# Altium schematic sheet 06_ACCELEROMETER_FLASH_WIND.SchDoc(06_ACCELEROMETER_FLASH_WIND)
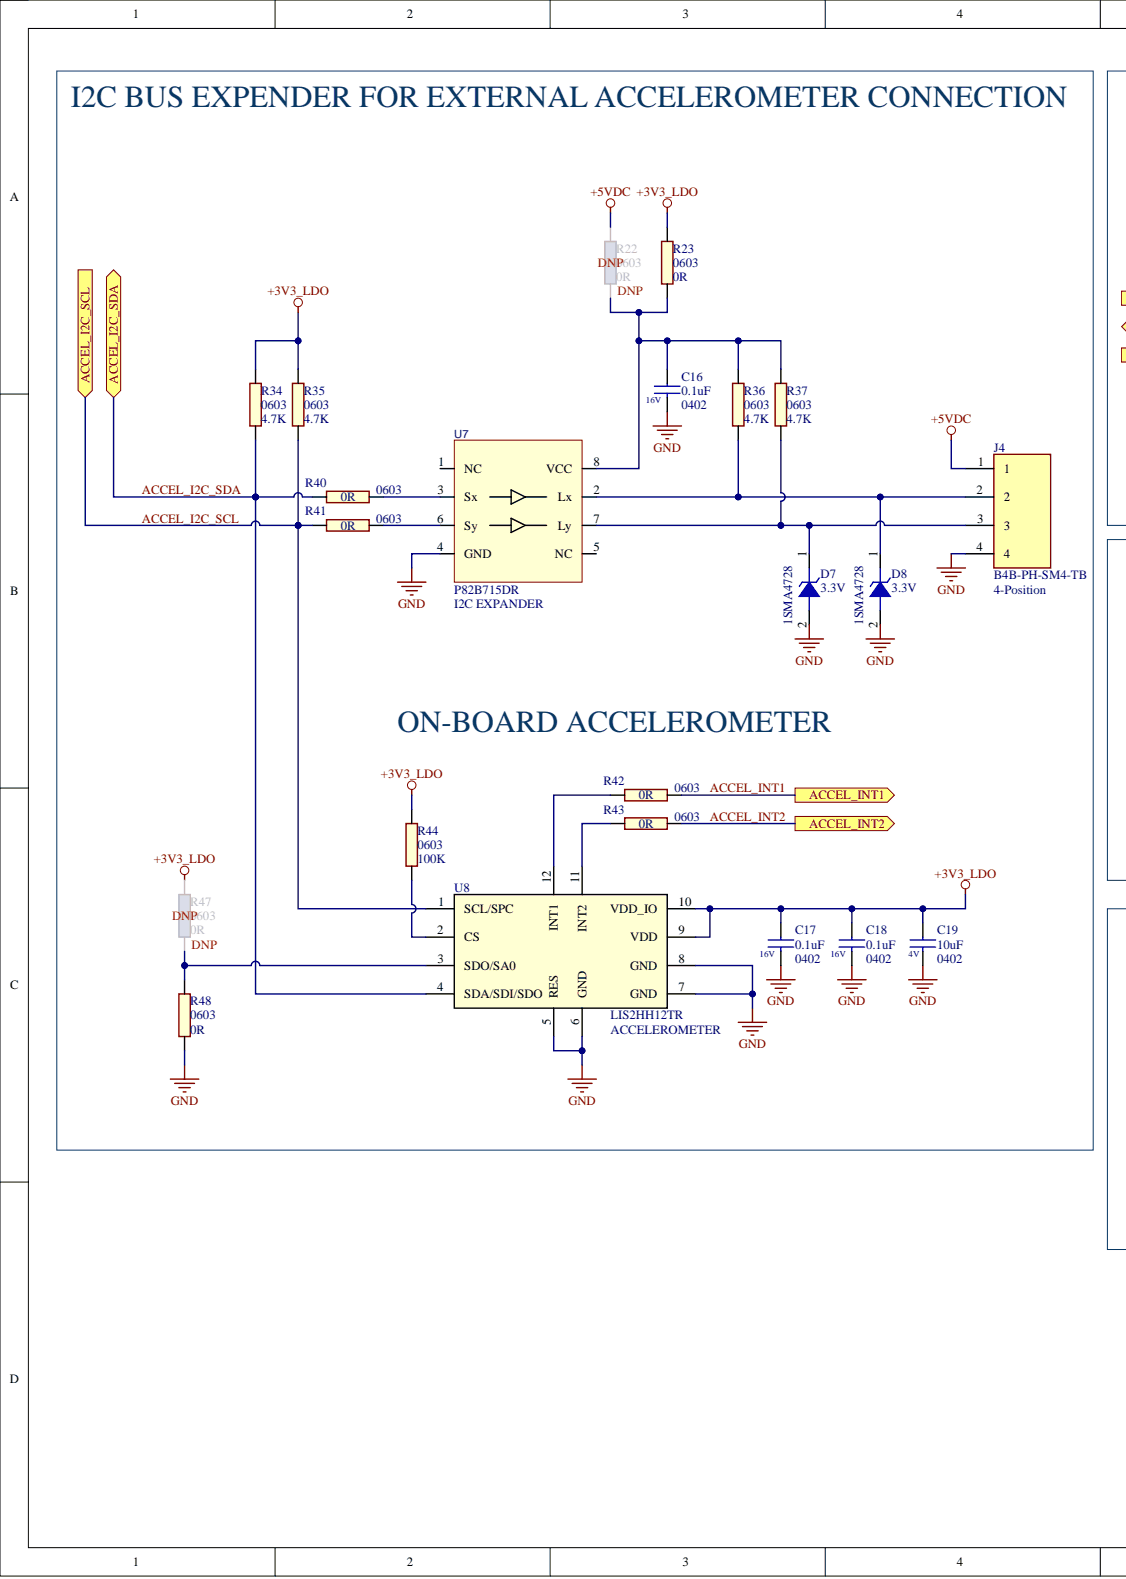
[diagram p0: 06_ACCELEROMETER_FLASH_WIND.SchDoc, left]
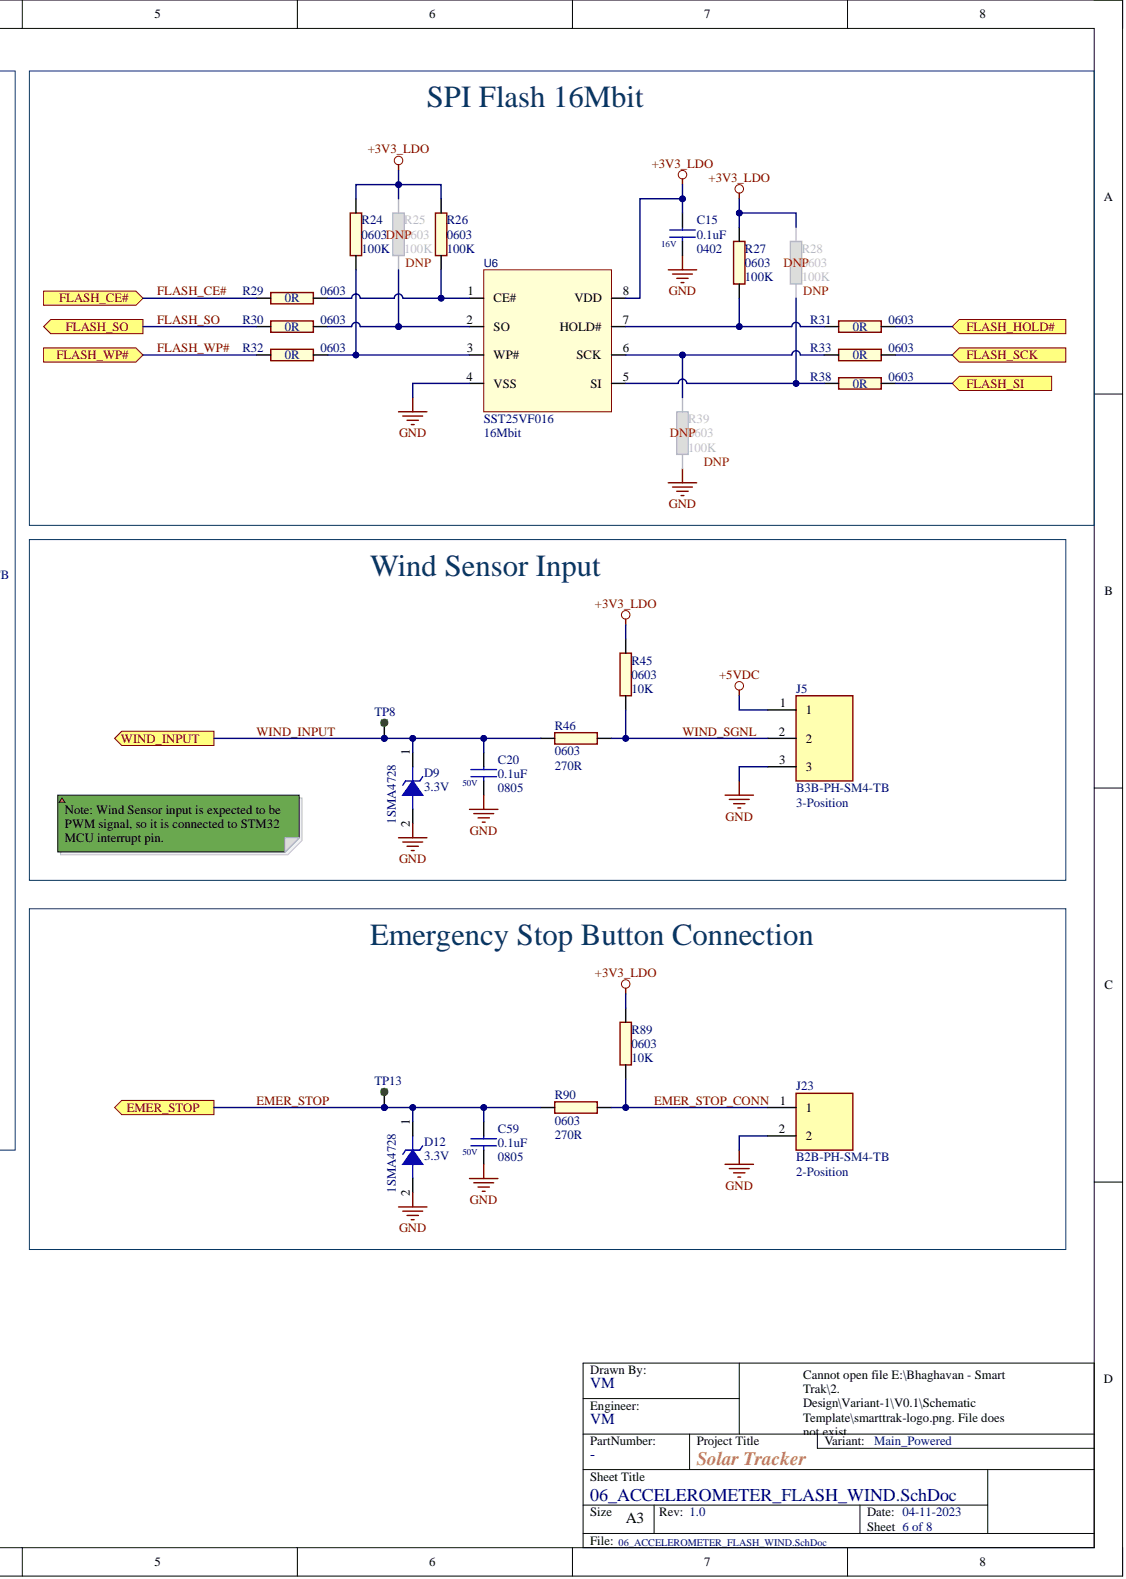
[diagram p1: 06_ACCELEROMETER_FLASH_WIND.SchDoc, right]

## Parts
C15  value 0.1uF  Note: 0402  Voltage - Rated: 16V  [C0402]  (hidden comment: CAP_0.1uF_0402_16V)
C16  value 0.1uF  Note: 0402  Voltage - Rated: 16V  [C0402]  (hidden comment: CAP_0.1uF_0402_16V)
C17  value 0.1uF  Note: 0402  Voltage - Rated: 16V  [C0402]  (hidden comment: CAP_0.1uF_0402_16V)
C18  value 0.1uF  Note: 0402  Voltage - Rated: 16V  [C0402]  (hidden comment: CAP_0.1uF_0402_16V)
C19  value 10uF  Note: 0402  Voltage - Rated: 4V  [C0402]  (hidden comment: CAP_10uF_0402_4V)
C20  value 0.1uF  Note: 0805  Voltage - Rated: 50V  [C0805]  (hidden comment: CAP_0.1uF_0805_50V)
C59  value 0.1uF  Note: 0805  Voltage - Rated: 50V  [C0805]  (hidden comment: CAP_0.1uF_0805_50V)
D7  value 1SMA4728  Note: 3.3V  [SOD5027X250N_2A]  (hidden comment: ZENER_SMD_3.3V_1SMA4728)
D8  value 1SMA4728  Note: 3.3V  [SOD5027X250N_2A]  (hidden comment: ZENER_SMD_3.3V_1SMA4728)
D9  value 1SMA4728  Note: 3.3V  [SOD5027X250N_2A]  (hidden comment: ZENER_SMD_3.3V_1SMA4728)
D12  value 1SMA4728  Note: 3.3V  [SOD5027X250N_2A]  (hidden comment: ZENER_SMD_3.3V_1SMA4728)
J4  value B4B-PH-SM4-TB  MPN: B4B-PH-SM4-TB  Note: 4-Position  [JST_B4B-PH-SM4-TB]  (hidden comment: CONN_4PIN_B4B-PH-SM4-TB)
J5  value B3B-PH-SM4-TB  MPN: B3B-PH-SM4-TB  Note: 3-Position  [JST_B3B-PH-SM4-TB]  (hidden comment: CONN_3PIN_B3B-PH-SM4-TB)
J23  value B2B-PH-SM4-TB  MPN: B2B-PH-SM4-TB  Note: 2-Position  [JST_B2B-PH-SM4-TB]  (hidden comment: CONN_2PIN_B2B-PH-SM4-TB)
R22  value 0R  Note: 0603  [R0603]  (hidden comment: RES_0R_0603)
R23  value 0R  Note: 0603  [R0603]  (hidden comment: RES_0R_0603)
R24  value 100K  Note: 0603  [R0603]  (hidden comment: RES_100K_0603)
R25  value 100K  Note: 0603  [R0603]  (hidden comment: RES_100K_0603)
R26  value 100K  Note: 0603  [R0603]  (hidden comment: RES_100K_0603)
R27  value 100K  Note: 0603  [R0603]  (hidden comment: RES_100K_0603)
R28  value 100K  Note: 0603  [R0603]  (hidden comment: RES_100K_0603)
R29  value 0R  Note: 0603  [R0603]  (hidden comment: RES_0R_0603)
R30  value 0R  Note: 0603  [R0603]  (hidden comment: RES_0R_0603)
R31  value 0R  Note: 0603  [R0603]  (hidden comment: RES_0R_0603)
R32  value 0R  Note: 0603  [R0603]  (hidden comment: RES_0R_0603)
R33  value 0R  Note: 0603  [R0603]  (hidden comment: RES_0R_0603)
R34  value 4.7K  Note: 0603  [R0603]  (hidden comment: RES_4.7K_0603)
R35  value 4.7K  Note: 0603  [R0603]  (hidden comment: RES_4.7K_0603)
R36  value 4.7K  Note: 0603  [R0603]  (hidden comment: RES_4.7K_0603)
R37  value 4.7K  Note: 0603  [R0603]  (hidden comment: RES_4.7K_0603)
R38  value 0R  Note: 0603  [R0603]  (hidden comment: RES_0R_0603)
R39  value 100K  Note: 0603  [R0603]  (hidden comment: RES_100K_0603)
R40  value 0R  Note: 0603  [R0603]  (hidden comment: RES_0R_0603)
R41  value 0R  Note: 0603  [R0603]  (hidden comment: RES_0R_0603)
R42  value 0R  Note: 0603  [R0603]  (hidden comment: RES_0R_0603)
R43  value 0R  Note: 0603  [R0603]  (hidden comment: RES_0R_0603)
R44  value 100K  Note: 0603  [R0603]  (hidden comment: RES_100K_0603)
R45  value 10K  Note: 0603  [R0603]  (hidden comment: RES_10K_0603)
R46  value 270R  Note: 0603  [R0603]  (hidden comment: RES_270R_0603)
R47  value 0R  Note: 0603  [R0603]  (hidden comment: RES_0R_0603)
R48  value 0R  Note: 0603  [R0603]  (hidden comment: RES_0R_0603)
R89  value 10K  Note: 0603  [R0603]  (hidden comment: RES_10K_0603)
R90  value 270R  Note: 0603  [R0603]  (hidden comment: RES_270R_0603)
TP8  TP_GREEN_5121  [KEYSTONE_5121]  (value not drawn)
TP13  TP_GREEN_5121  [KEYSTONE_5121]  (value not drawn)
U6  value SST25VF016  Note: 16Mbit  [SOIC127P800X216-8N]  (hidden comment: FLASH_16MBIT_SST25VF016)
U7  value P82B715DR  MPN: P82B715DR  Note: I2C EXPANDER  [SOIC127P600X175-8N]  (hidden comment: REDRIVER_I2C_P82B715DR)
U8  value LIS2HH12TR  MPN: LIS2HH12TR  Note: ACCELEROMETER  [LGA12S50P4X4_200X200X100N]  (hidden comment: ACCEELROMETER_LIS2HH12TR)

## Ports
ACCEL_I2C_SCL, ACCEL_I2C_SDA, ACCEL_INT1, ACCEL_INT2, EMER_STOP, FLASH_CE#, FLASH_HOLD#, FLASH_SCK, FLASH_SI, FLASH_SO, FLASH_WP#, WIND_INPUT

## Nets
+3V3_LDO: C15.1 C17.1 C18.1 C19.1 R23.2 R24.2 R25.2 R26.2 R27.2 R28.2 R34.2 R35.2 R44.2 R45.2 R47.2 R89.2 U6.8(VDD) U8.9(VDD) U8.10(VDD_IO)
+5VDC: J4.1 J5.1 R22.2
GND: C15.2 C16.2 C17.2 C18.2 C19.2 C20.2 C59.2 D7.2 D8.2 D9.2 D12.2 J4.4 J5.3 J23.2 R39.1 R48.1 U6.4(VSS) U7.4(GND) U8.5(RES) U8.6(GND) U8.7(GND) U8.8(GND)
ACCEL_I2C_SCL: R35.1 R41.1 U8.1(SCL/SPC) ; port ACCEL_I2C_SCL
ACCEL_I2C_SDA: R34.1 R40.1 U8.4(SDA/SDI/SDO) ; port ACCEL_I2C_SDA
ACCEL_INT1: R42.2 ; port ACCEL_INT1
ACCEL_INT2: R43.2 ; port ACCEL_INT2
EMER_STOP: C59.1 D12.1 R90.1 TP13.1 ; port EMER_STOP
EMER_STOP_CONN: J23.1 R89.1 R90.2
FLASH_CE#: R29.1 ; port FLASH_CE#
FLASH_HOLD#: R31.2 ; port FLASH_HOLD#
FLASH_SCK: R33.2 ; port FLASH_SCK
FLASH_SI: R38.2 ; port FLASH_SI
FLASH_SO: R30.1 ; port FLASH_SO
FLASH_WP#: R32.1 ; port FLASH_WP#
WIND_INPUT: C20.1 D9.1 R46.1 TP8.1 ; port WIND_INPUT
WIND_SGNL: J5.2 R45.1 R46.2
NetC16_1: C16.1 R22.1 R23.1 R36.2 R37.2 U7.8(VCC)
NetD7_1: D7.1 J4.3 R37.1 U7.7(Ly)
NetD8_1: D8.1 J4.2 R36.1 U7.2(Lx)
NetR24_1: R24.1 R32.2 U6.3(WP#)
NetR25_1: R25.1 R30.2 U6.2(SO)
NetR26_1: R26.1 R29.2 U6.1(CE#)
NetR27_1: R27.1 R31.1 U6.7(HOLD#)
NetR28_1: R28.1 R38.1 U6.5(SI)
NetR33_1: R33.1 R39.2 U6.6(SCK)
NetR40_2: R40.2 U7.3(Sx)
NetR41_2: R41.2 U7.6(Sy)
NetR42_1: R42.1 U8.12(INT1)
NetR43_1: R43.1 U8.11(INT2)
NetR44_1: R44.1 U8.2(CS)
NetR47_1: R47.1 R48.2 U8.3(SDO/SA0)

Not connected: U7 1(NC) 5(NC)

## Library data of the parts
C15-C18 = CAP_0.1uF_0402_16V: CAP CER 0.1UF 16V X7R 0402; Manufacturer: Yageo; MPN: CC0402KRX7R7BB104; Component Kind: Standard; Library Name: Project.SchLib
C19 = CAP_10uF_0402_4V: CAP CER 10UF 4V X5R 0402; Manufacturer: Yageo; MPN: CC0402MRX5R4BB106; Component Kind: Standard; Library Name: Project.SchLib
C20 C59 = CAP_0.1uF_0805_50V: CAP CER 0.1UF 50V X7R 0805; Manufacturer: KEMET; MPN: C0805C104K5RAC7800; Component Kind: Standard; Library Name: Project.SchLib
D7-D9 D12 = ZENER_SMD_3.3V_1SMA4728: DIODE ZENER 3.3V 1W  SMA (DO-214AC); Manufacturer: onsemi; MPN: 1SMA4728-AU_R1_000A1; Component Kind: Standard; Library Name: Project.SchLib
J4 = CONN_4PIN_B4B-PH-SM4-TB: CONN HEADER SMD 4POS 2MM; Manufacturer: JST; Component Kind: Standard; Library Name: Project.SchLib
J5 = CONN_3PIN_B3B-PH-SM4-TB: CONN HEADER SMD 3POS 2MM; Manufacturer: JST; Component Kind: Standard; Library Name: Project.SchLib
J23 = CONN_2PIN_B2B-PH-SM4-TB: CONN HEADER SMD 2POS 2MM; Manufacturer: JST; Component Kind: Standard; Library Name: Project.SchLib
R22 R23 R29-R33 R38 R40-R43 R47 R48 = RES_0R_0603: RES SMD 0 OHM JUMPER 1/10W 0603; Manufacturer: Yageo; MPN: RC0603JR-070RL; Component Kind: Standard; Library Name: Project.SchLib
R24-R28 R39 R44 = RES_100K_0603: RES 100K OHM 5% 1/10W 0603; Manufacturer: Yageo; MPN: RC0603JR-07100KL; Component Kind: Standard; Library Name: Project.SchLib
R34-R37 = RES_4.7K_0603: RES 4.7K OHM 5% 1/10W 0603; Manufacturer: Yageo; MPN: RC0603JR-074K7L; Component Kind: Standard; Library Name: Project.SchLib
R45 R89 = RES_10K_0603: RES 10K OHM 5% 1/10W 0603; Manufacturer: Yageo; MPN: RC0603JR-0710KL; Component Kind: Standard; Library Name: Project.SchLib
R46 R90 = RES_270R_0603: RES 270 OHM 5% 1/10W 0603; Manufacturer: Yageo; MPN: RC0603JR-07270RL; Component Kind: Standard; Library Name: Project.SchLib
TP8 TP13 = TP_GREEN_5121: PC TEST POINT COMPACT GREEN; Manufacturer: Keystone Electronics; MPN: 5121; Value: 5121; Component Kind: Standard; Library Name: Project.SchLib; Note: -
U6 = FLASH_16MBIT_SST25VF016: IC FLASH 16MBIT SPI 50MHZ 8SOIC; Manufacturer: Microchip Technology; MPN: SST25VF016B-50-4C-S2AF-T; Component Kind: Standard; Library Name: Project.SchLib
U7 = REDRIVER_I2C_P82B715DR: IC REDRIVER I2C 1CH 8SOIC; Manufacturer: Texas Instruments; Component Kind: Standard; Library Name: Project.SchLib
U8 = ACCEELROMETER_LIS2HH12TR: ACCELEROMETER 2-8G I2C/SPI 12LGA; Manufacturer: STMicroelectronics; Component Kind: Standard; Library Name: Project.SchLib
